# Altium schematic sheet Placa Adaptadora_R1.1.SchDoc
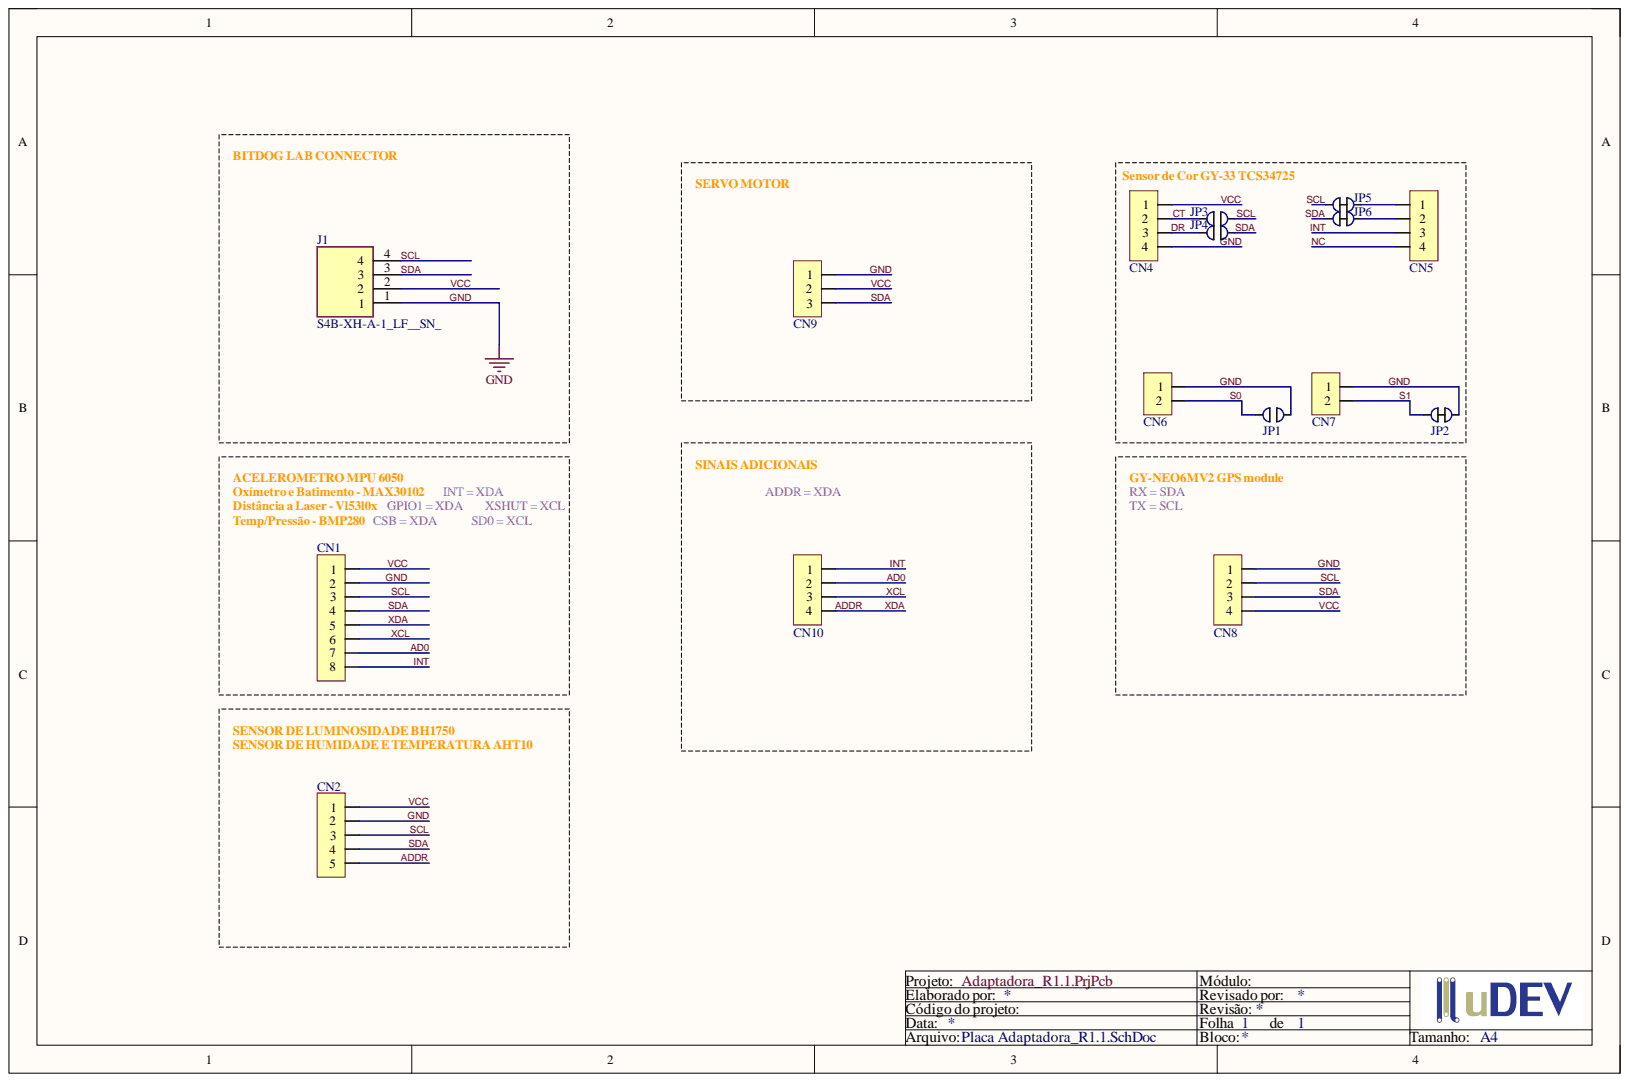
[diagram p0: Placa Adaptadora_R1.1.SchDoc]

## Parts
CN1  HD 1X8 HOR  [HEADER 8 HOR 2.54mm]  (value not drawn)
CN2  HEADER 1x5 V 2.54MM  [HEADER5_VERTICAL]  (value not drawn)
CN4  HD 1XV HOR  [HEADER4 VERT]  (value not drawn)
CN5  HD 1XV HOR  [HEADER4 VERT]  (value not drawn)
CN6  HD 1X2 HOR  [HEADER2 VERT]  (value not drawn)
CN7  HD 1X2 HOR  [HEADER2 VERT]  (value not drawn)
CN8  HD 1XV HOR  [HEADER4 VERT]  (value not drawn)
CN9  HD 1X3 HOR  [HEADER3 VERT]  (value not drawn)
CN10  HD 1XV HOR  [HEADER4 VERT]  (value not drawn)
J1  S4B-XH-A-1_LF__SN_  [S4BXHA1LFSN]
JP1  JUMPER CLOSER  [Jumper_OPEN]  (value not drawn)
JP2  JUMPER CLOSER  [Jumper_Closer]  (value not drawn)
JP3  JUMPER CLOSER  [Jumper_OPEN]  (value not drawn)
JP4  JUMPER CLOSER  [Jumper_OPEN]  (value not drawn)
JP5  JUMPER CLOSER  [Jumper_Closer]  (value not drawn)
JP6  JUMPER CLOSER  [Jumper_Closer]  (value not drawn)

## Nets
AD0: CN1.7 CN10.2
ADDR: CN1.5 CN2.5 CN10.4
CT: CN4.2 JP3.1
DR: CN4.3 JP4.1
GND: CN1.2 CN2.2 CN4.4 CN6.1 CN7.1 CN8.1 CN9.1 J1.1 JP1.2 JP2.1
INT: CN1.8 CN5.3 CN10.1
NC: CN5.4
S0: CN6.2 JP1.1
S1: CN7.2 JP2.2
SCL: CN1.3 CN2.3 CN8.2 J1.4 JP3.2 JP5.2
SDA: CN1.4 CN2.4 CN8.3 CN9.3 J1.3 JP4.2 JP6.2
VCC: CN1.1 CN2.1 CN4.1 CN8.4 CN9.2 J1.2
XCL: CN1.6 CN10.3
NetCN5_1: CN5.1 JP5.1
NetCN5_2: CN5.2 JP6.1

## Library data of the parts
CN1 = HEADER 1x8 V 2.54MM: CONN HEADER 8POS VERT 2.5MM; Component Kind: Standard; Library Name: Conectores.SchLib
CN2 = HEADER 1x5 H 2.54MM: CONN HEADER 5POS V 2.5MM; Component Kind: Standard; Library Name: Conectores.SchLib
CN4 CN5 CN8 CN10 = HEADER 1x4 V 2.54MM: CONN HEADER 4POS VERT 2.5MM; Component Kind: Standard; Library Name: Conectores.SchLib
CN6 CN7 = HEADER 1x2 v 2.54MM: CONN HEADER 2POS VERT 2.54MM; Component Kind: Standard; Library Name: Conectores.SchLib
CN9 = HEADER 1x3 v 2.54MM: CONN HEADER 3POS VERT 2.54MM; Manufacturer: TE Connectivity; Component Kind: Standard; Library Name: Conectores.SchLib
J1 = S4B-XH-A-1_LF__SN_: CONN HEADER R/A 4POS 2.5MM; Manufacturer: JST (JAPAN SOLDERLESS TERMINALS); Manufacturer PN: S4B-XH-A-1(LF)(SN); Arrow Part Number: S4B-XH-A-1(LF)(SN); Arrow Price/Stock: https://www.arrow.com/en/products/s4b-xh-a-1-lf-sn/jst-manufacturing; Component Kind: Standard; Datasheet Link: https://datasheet.datasheetarchive.com/originals/distributors/Datasheets_SAMA/45bda73f655da0a850bf2ea3e93b2e86.pdf; Height: 6.1mm; Mouser Part Number: 306-S4BXHA1LFSN; Mouser Price/Stock: https://www.mouser.co.uk/ProductDetail/JST-Commercial/S4B-XH-A-1LFSN?qs=QpmGXVUTftHhkkOLv9XcQA%3D%3D
JP1 JP3 JP4 = JSO: Component Kind: Standard; Description: JUMPER CLOSER; Library Name: SamacSys.SchLib
JP2 JP5 JP6 = JSC: Component Kind: Standard; Description: JUMPER CLOSER; Library Name: SamacSys.SchLib
